# Altium schematic sheet ZW Radio.SchDoc("ZW Radio")
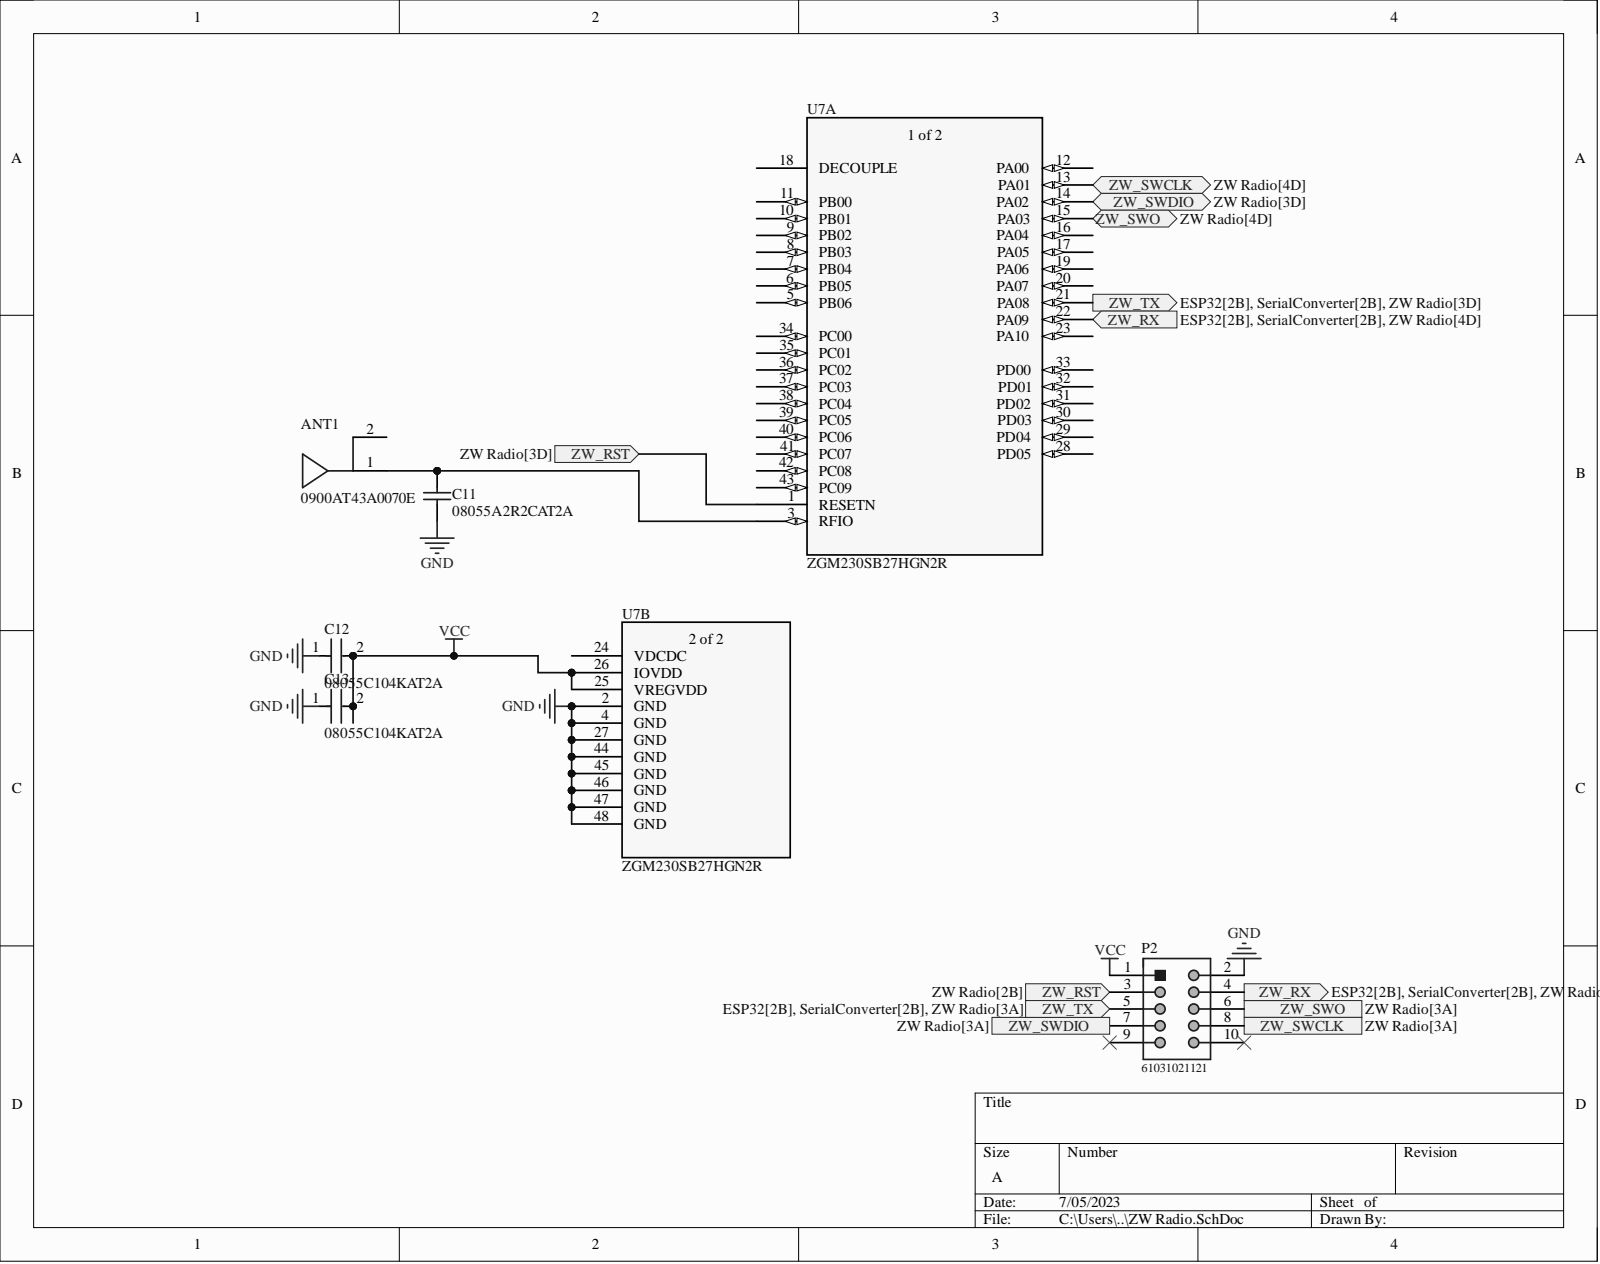
[diagram p0: ZW Radio.SchDoc]

## Parts
ANT1  0900AT43A0070E  [FP-0900AT43A0070E-MFG]
C11  08055A2R2CAT2A  [CAPC2013X94X50NL20T25]
C12  08055C104KAT2A  [FP-0805-L_2_01_0_2-W_1_25-IPC_C]
C13  08055C104KAT2A  [FP-0805-L_2_01_0_2-W_1_25-IPC_C]
P2  61031021121  [61031021121]
U7  ZGM230SB27HGN2R  [QFN44_ZGM230S_SIL]  (parts A,B)

## Ports
ZW_RST, ZW_RX, ZW_SWCLK, ZW_SWDIO, ZW_SWO, ZW_TX

## Nets
GND: C11.1 C12.1 C13.1 P2.2 U7.2(GND) U7.4(GND) U7.27(GND) U7.44(GND) U7.45(GND) U7.46(GND) U7.47(GND) U7.48(GND)
VCC: C12.2 C13.2 P2.1 U7.25(VREGVDD) U7.26(IOVDD)
ZW_RST: P2.3 U7.1(RESETN) ; port ZW_RST
ZW_RX: P2.4 U7.22(PA09) ; port ZW_RX
ZW_SWCLK: P2.8 U7.13(PA01) ; port ZW_SWCLK
ZW_SWDIO: P2.7 U7.14(PA02) ; port ZW_SWDIO
ZW_SWO: P2.6 U7.15(PA03) ; port ZW_SWO
ZW_TX: P2.5 U7.21(PA08) ; port ZW_TX
NetANT1_1: ANT1.1 C11.2 U7.3(RFIO)

Not connected: ANT1 2; P2 9 10; U7 5(PB06) 6(PB05) 7(PB04) 8(PB03) 9(PB02) 10(PB01) 11(PB00) 12(PA00) 16(PA04) 17(PA05) 18(DECOUPLE) 19(PA06) 20(PA07) 23(PA10) 24(VDCDC) 28(PD05) 29(PD04) 30(PD03) 31(PD02) 32(PD01) 33(PD00) 34(PC00) 35(PC01) 36(PC02) 37(PC03) 38(PC04) 39(PC05) 40(PC06) 41(PC07) 42(PC08) 43(PC09)

## Library data of the parts
ANT1 = CMP-56579-000002-1: RF ANT CHIP SOLDER SMD; Impedance: 50R; Max Frequency: 928MHz; Component Kind: Standard; Contact Plating: Tin; Library Name: Altium Content Vault; Mount: Surface Mount; Number of Pins: 2; RoHS Compliant: No
C11 = CMP-1036-00283-2: Manufacturer: AVX Interconnect / Elco; Component Kind: Standard; Library Name: Altium Content Vault; Part Number: 08055A2R2CAT2A
C12 C13 = CMP-2000-05016-2: General Purpose Ceramic Capacitor, 0805, 100nF, 10%, X7R, 0.15, 50V; Dissipation Factor: 2.5%; Insulation Resistance: 100GR; Capacitance: 100nF; Case Code (Imperial): 0805; Case Code (Metric): 2012; Case/Package: 0805; Component Kind: Standard; Depth: 1.25mm; Dielectric: X7R; Dielectric Material: Ceramic; Height: 0.5mm; Lead Free: Lead Free; Length: 2mm; Library Name: Altium Content Vault; Max Operating Temperature: 125°C; Min Operating Temperature: -55°C; Mount: Surface Mount; Number of Pins: 2; Package Quantity: 4000; Packaging: Cut Tape; Radiation Hardening: No; REACH SVHC: No SVHC; RoHS Compliant: Yes; Series: MLCC X7R; Termination: Standard; Thickness: 0.037inch; Tolerance: 10%; Voltage: 50V; Voltage Rating: 50V; Voltage Rating (DC): 50V; Weight: 0.001411oz; Width: 1.2mm
P2 = CMP-1502-01053-1: SMT Vertical Pin Header WR-PHD, Pitch 2.54 mm, Dual Row, 10 pins; Manufacturer: Wurth Elektronik; Contact Resistance: 20mOhm; Gender: Male; Pin Number: 10; Pitch: 2.54mm; Rated Current: 3A; Withstanding Voltage: 500VAC; Working Voltage: 250VAC; Component Kind: Standard; Datasheet URL: https://katalog.we-online.de/em/datasheet/6103xx21121.pdf; Library Name: Altium Content Vault; Manufacturer URL: http://www.we-online.de; Mounting Technology: SMT; Operating Temperature: -40to125degC; Orientation: Vertical; Package Reference: 61031021121; Packaging: TapeandReel; Part Number: 61031021121; Row Number: Dual; Publisher: WurthElektronik
U7 = CMP-009-000001-5: No Description Available; Mfr_Name: Silicon Labs; Component Kind: Standard; Design Item ID: ZGM230SB27HGN2R; RefDes: RefDes; Source: ZGM230SB27HGN2R.SchLib; Type: Interface; Library Name: Purdue University
